# Altium schematic sheet Vapeix_mini_power.SchDoc
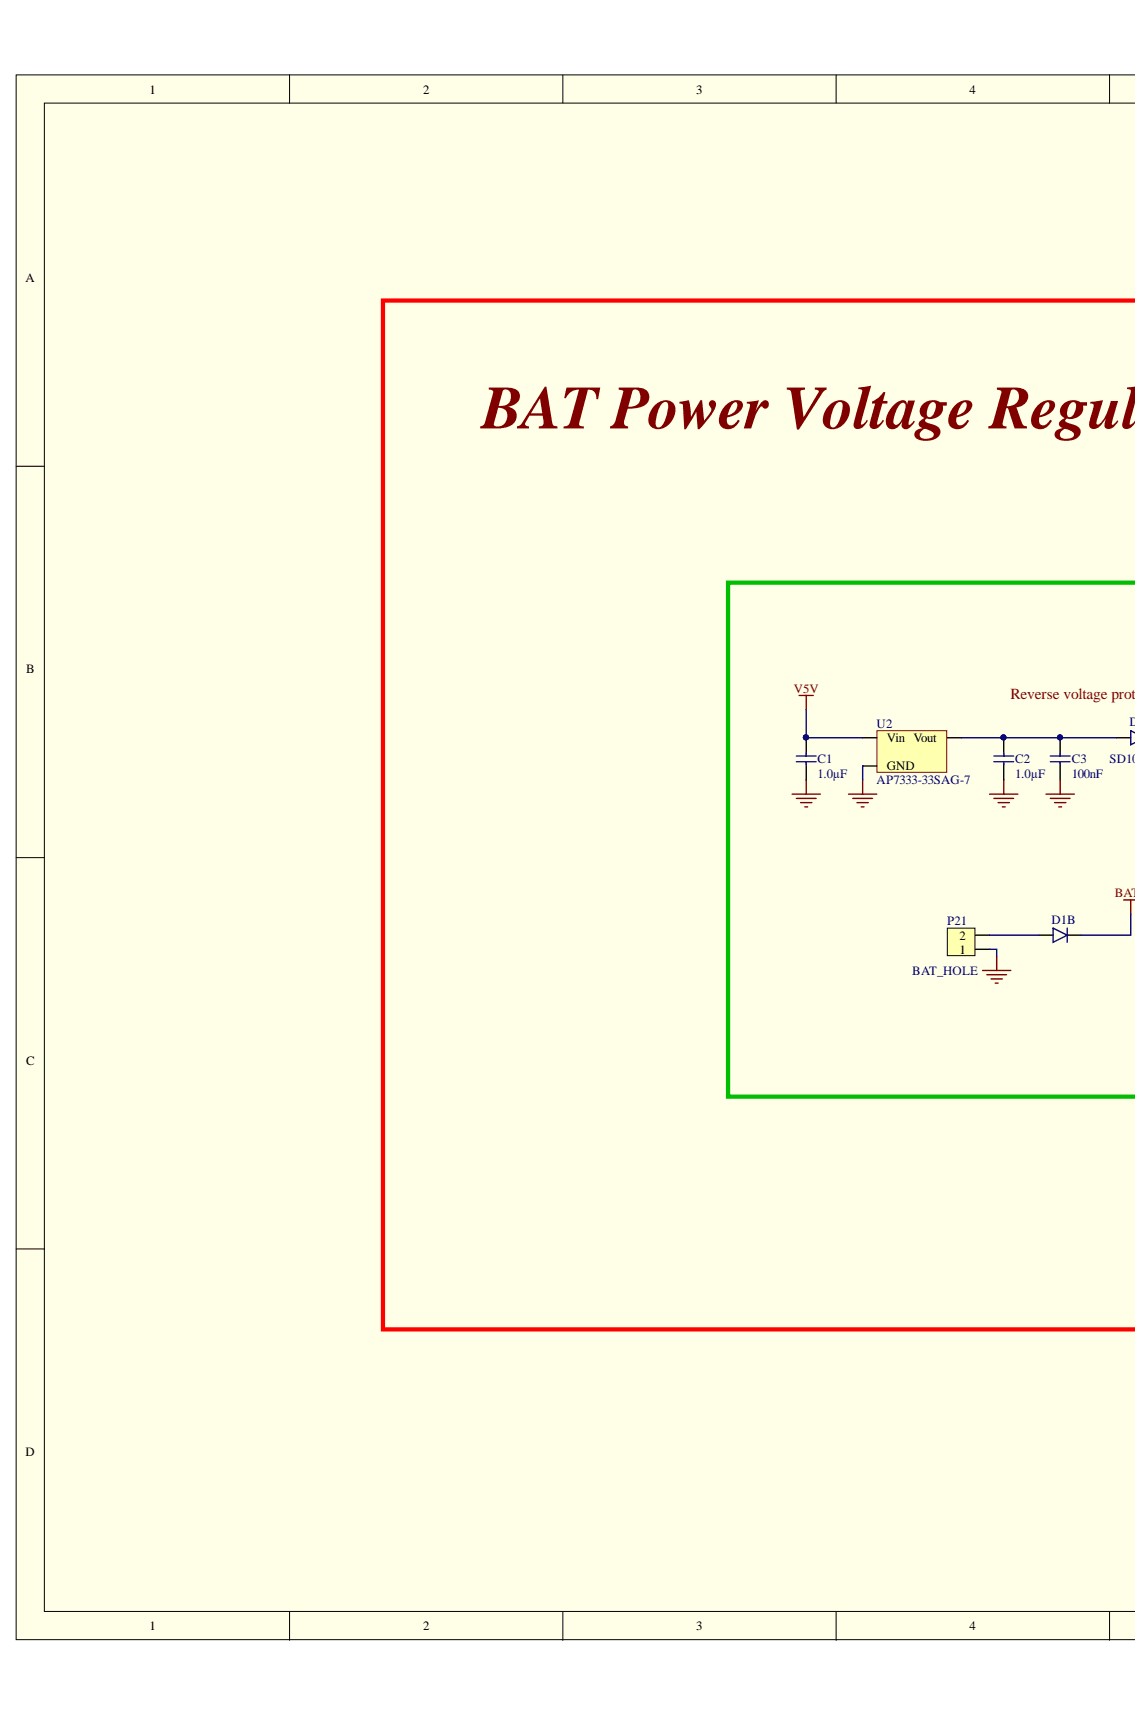
[diagram p0: Vapeix_mini_power.SchDoc, left]
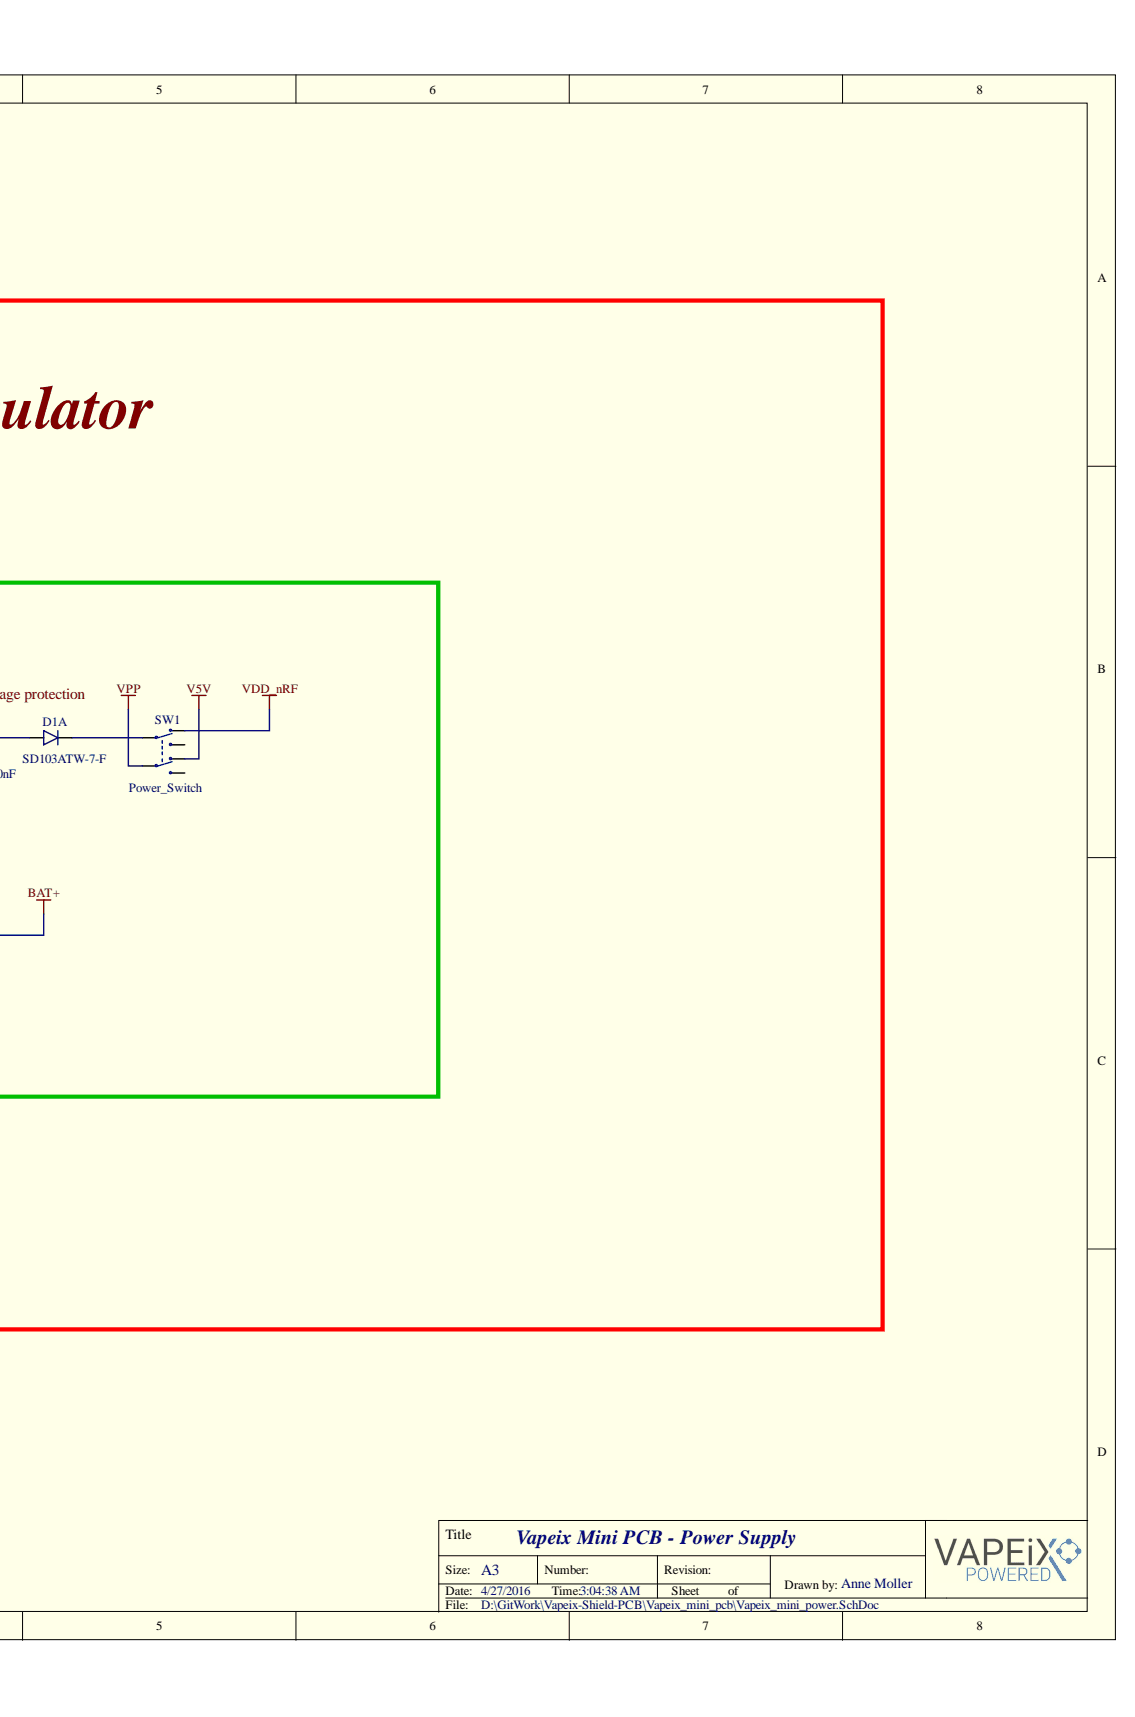
[diagram p1: Vapeix_mini_power.SchDoc, right]

## Parts
C1  1.0µF  [CAPC1608X06L]
C2  1.0µF  [CAPC1608X06L]
C3  100nF  [CAPC1005X04L]
D1  SD103ATW-7-F  [SOT-363]  (parts A,B)
P21  BAT_HOLE  [LIST_ANG_1x2-SMD-2.54MM]
SW1  Power_Switch  [JS202011SCQN]
U2  AP7333-33SAG-7  [SOT-23A]

## Nets
BAT+: D1.2
GND: C1.1 C2.1 C3.1 P21.1 U2.3
V5V: C1.2 SW1.6 U2.1
VDD_NRF: SW1.3
VPP: SW1.5
NetC2_2: C2.2 C3.2 D1.6 U2.2
NetD1_1: D1.1 SW1.2
NetD1_5: D1.5 P21.2
BAT POWER VOLTAGE REGULATOR:

Not connected: SW1 1 4

## Library data of the parts
C1 C2 = C0603-1µ0: Capacitor, X7R, ±10%; Artikkelnr Noca: 20039335; Artikkelnr Simpro: N.A.; Database Table Name: Capacitors$; Note: 16V; Component Kind: Standard; Footprint Doc: 0603; LatestRevisionDate: 2013-06-05; Library Name: Nordic Passive DB.DbLib; Part Number: C0603-1µ0; Pin Count: 2; Published: 2009-05-08; Value: 1.0µF; Publisher: Nordic Semiconductor
C3 = C0402-100n: Capacitor, X7R, ±10%; Artikkelnr Noca: 20039200; Artikkelnr Simpro: N.A.; Database Table Name: Capacitors$; Note: 16V; Component Kind: Standard; Footprint Doc: 0402; LatestRevisionDate: 2009-11-23; Library Name: Nordic Passive DB.DbLib; Part Number: C0402-100n; Pin Count: 2; Published: 2009-05-08; Value: 100nF; Publisher: Nordic Semiconductor
D1 = SD103ATW-7-F: Surface Mount Schottky Barrier Diode Array; 2nd source part number: 177-3507; Manufacturer: Diodes Incorporated; Artikkelnr Noca: 10029109; Artikkelnr Simpro: N.A.; Database Table Name: Semiconductors$; 2nd source Supplier: Farnell; Component Kind: Standard; Datasheet: http://www.diodes.com/datasheets/ds30374.pdf; Footprint Doc: SOT-363; LatestRevisionDate: 2012-06-15; Library Name: Nordic Discrete DB.DbLib; Manufacturer Part Number: SD103ATW-7-F; Part Number: SD103ATW-7-F; Published: 2012-06-15; Supplier: Digi-Key; Supplier part number: SD103ATW-FDITR-ND; Value: SD103ATW-7-F; Publisher: Nordic Semiconductor
P21 = Pin List Ang 1x2 SMD, 2.54mm: Pin List 1x2, 2.54mm (100mil), Right Angled, SMD; 2nd source part number: S1113E-02-ND; Artikkelnr Noca: N.A.; Database Table Name: Connectors$; 2nd source Supplier: Digi-Key; Artikkelnr Simpro: N.A.; Component Kind: Standard; Footprint Doc: LIST_ANG_1x2-SMD-2.54MM; LatestRevisionDate: 2014-04-30; Library Name: Nordic Passive DB.DbLib; Part Number: Pin List Ang 1x2 SMD, 2.54mm; Pin Count: 2; Published: 2014-04-30; Supplier: Samtec; Supplier part number: TSM-102-01-L-SH-A; Value: BAT_HOLE; Publisher: Nordic Semiconductor
SW1 = JS202011SCQN: SMD slide Switch (ON-ON); 2nd source part number: 232-0019; Manufacturer: C&K Components; Artikkelnr Noca: N.A.; Database Table Name: Rele_Switch$; 2nd source Supplier: Farnell; Artikkelnr Simpro: N.A.; Component Kind: Standard; Datasheet: http://www.ck-components.com/14398/js_14july11.pdf/; Footprint Doc: JS202011SCQN; LatestRevisionDate: 2014-06-24; Library Name: Nordic Discrete DB.DbLib; Manufacturer Part Number: JS202011SCQN; Part Number: JS202011SCQN; Pin Count: 6; Published: 2014-06-24; Supplier: Digi-Key; Supplier part number: 401-2002-1-ND; Value: Power_Switch; Publisher: Nordic Semiconductor
U2 = AP7333-33SAG-7: 300mA, Low Quiescent Current, Fast Transient Low Dropout Linear Regulator; Manufacturer: Diodes Incorporated; Artikkelnr Noca: 17039216; Artikkelnr Simpro: N.A.; Database Table Name: Misc IC$; Component Kind: Standard; Datasheet: http://www.diodes.com/datasheets/AP7333.pdf; Footprint Doc: SOT-23-3; LatestRevisionDate: 2012-06-15; Library Name: Nordic Active DB.DbLib; Manufacturer Part Number: AP7333-33SAG-7; Part Number: AP7333-33SAG-7; Pin Count: 3; Published: 2012-06-15; Supplier: Digi-Key; Supplier part number: AP7333-33SAG-7DICT-ND; Value: AP7333-33SAG-7; Publisher: Nordic Semiconductor
